# Altium schematic sheet RUMBA_plus.SchDoc
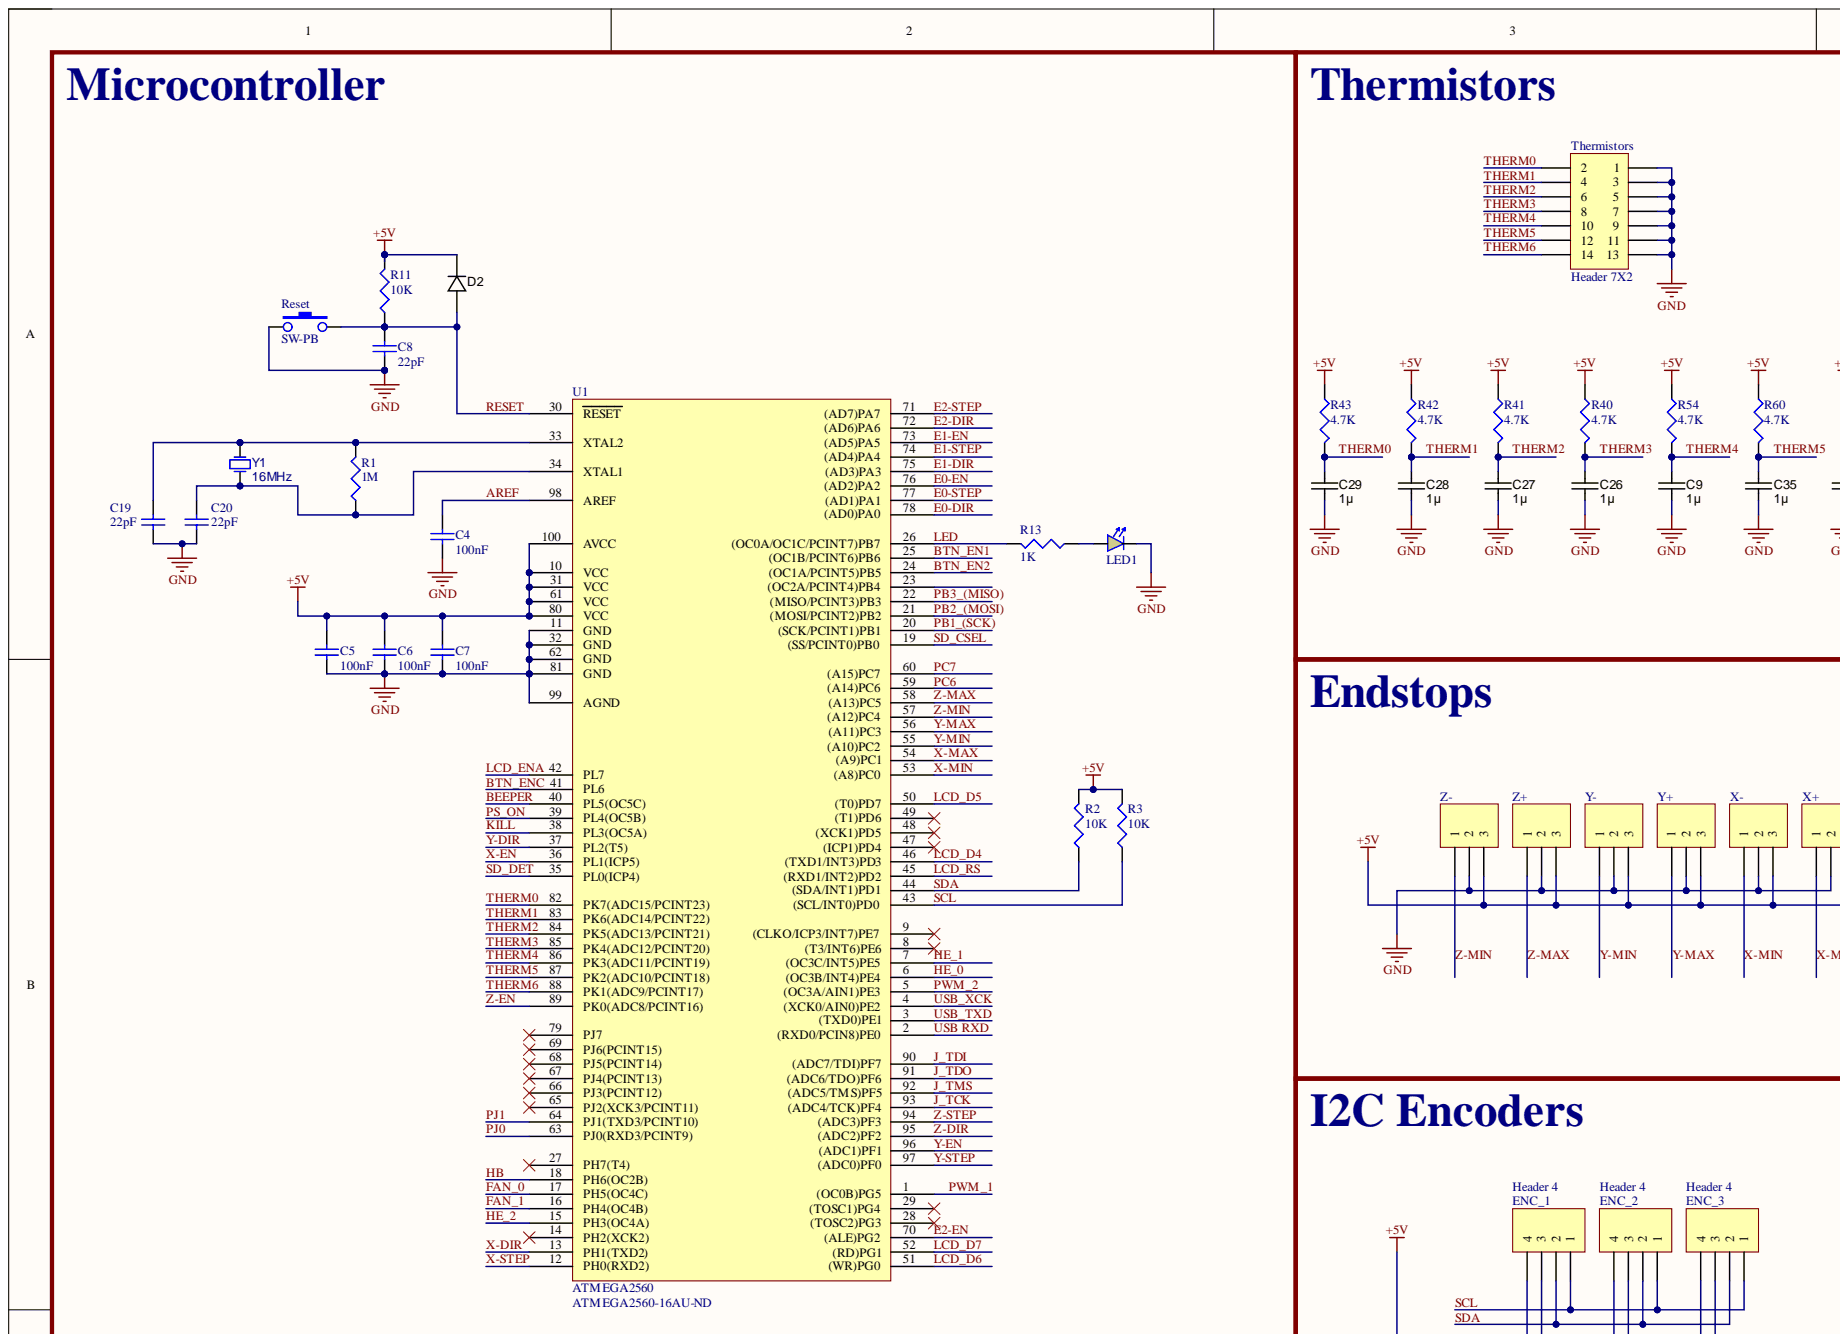
[diagram p0: RUMBA_plus.SchDoc, top left]
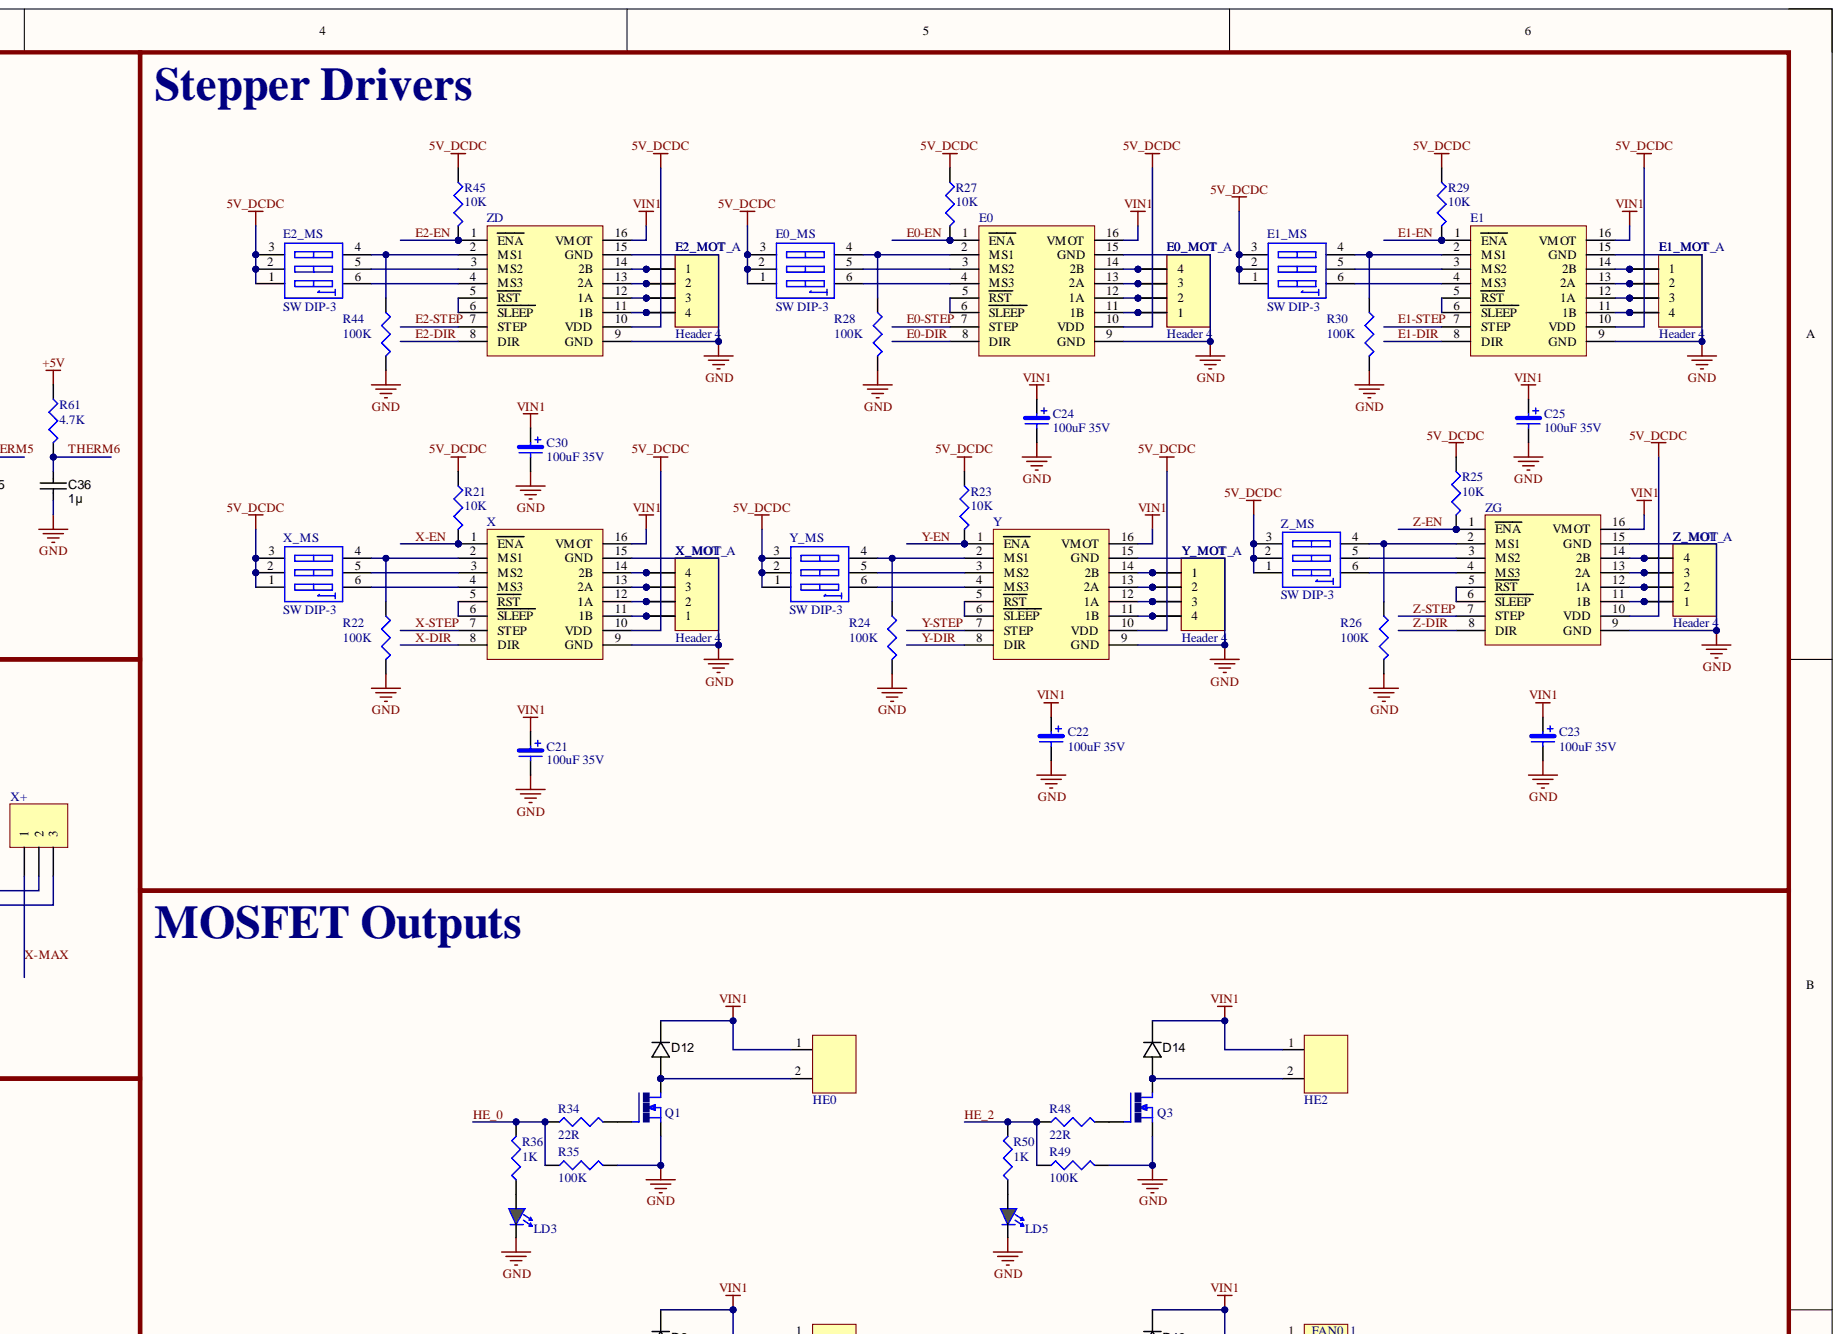
[diagram p1: RUMBA_plus.SchDoc, top right]
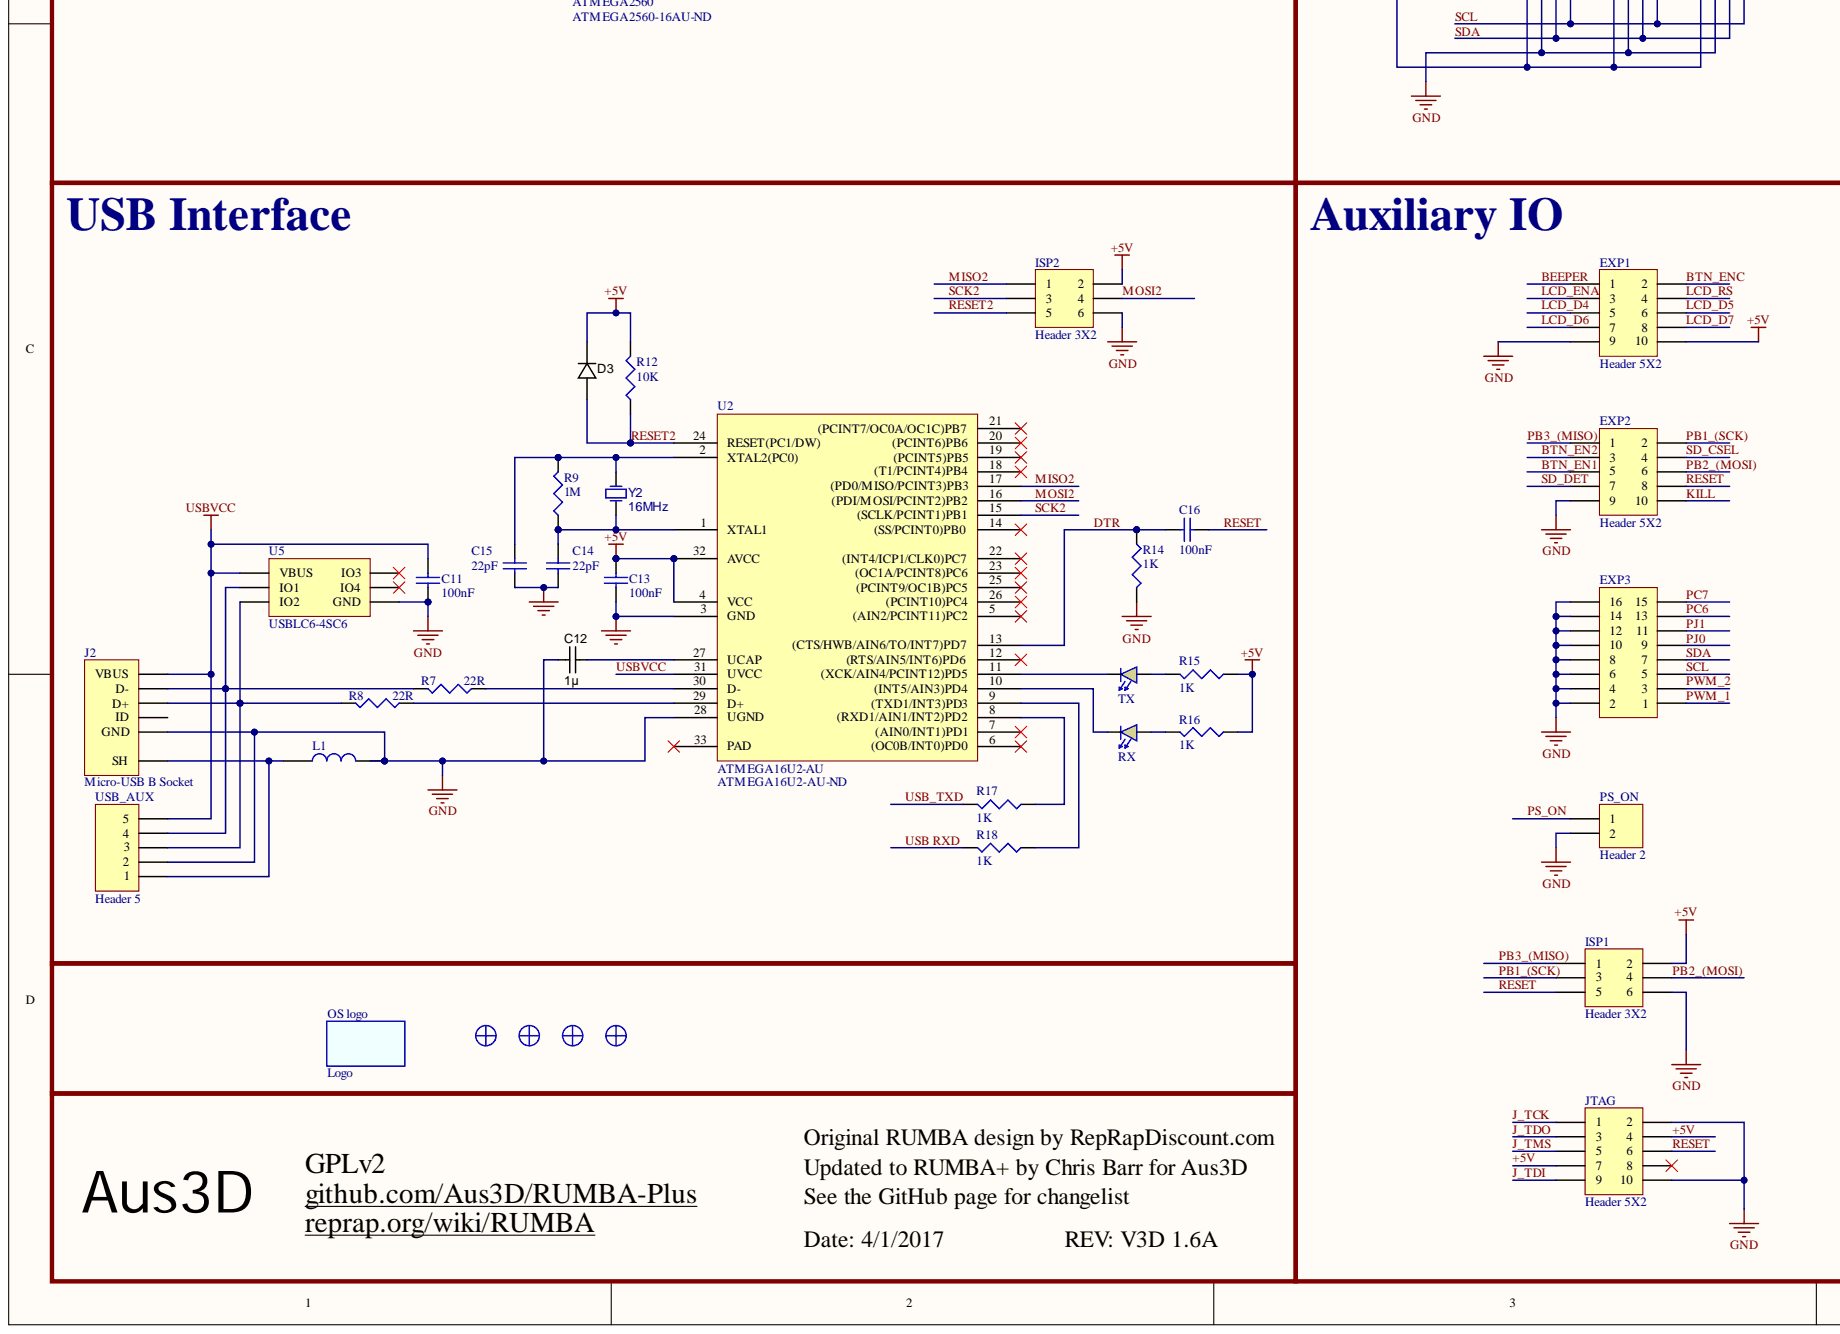
[diagram p2: RUMBA_plus.SchDoc, bottom left]
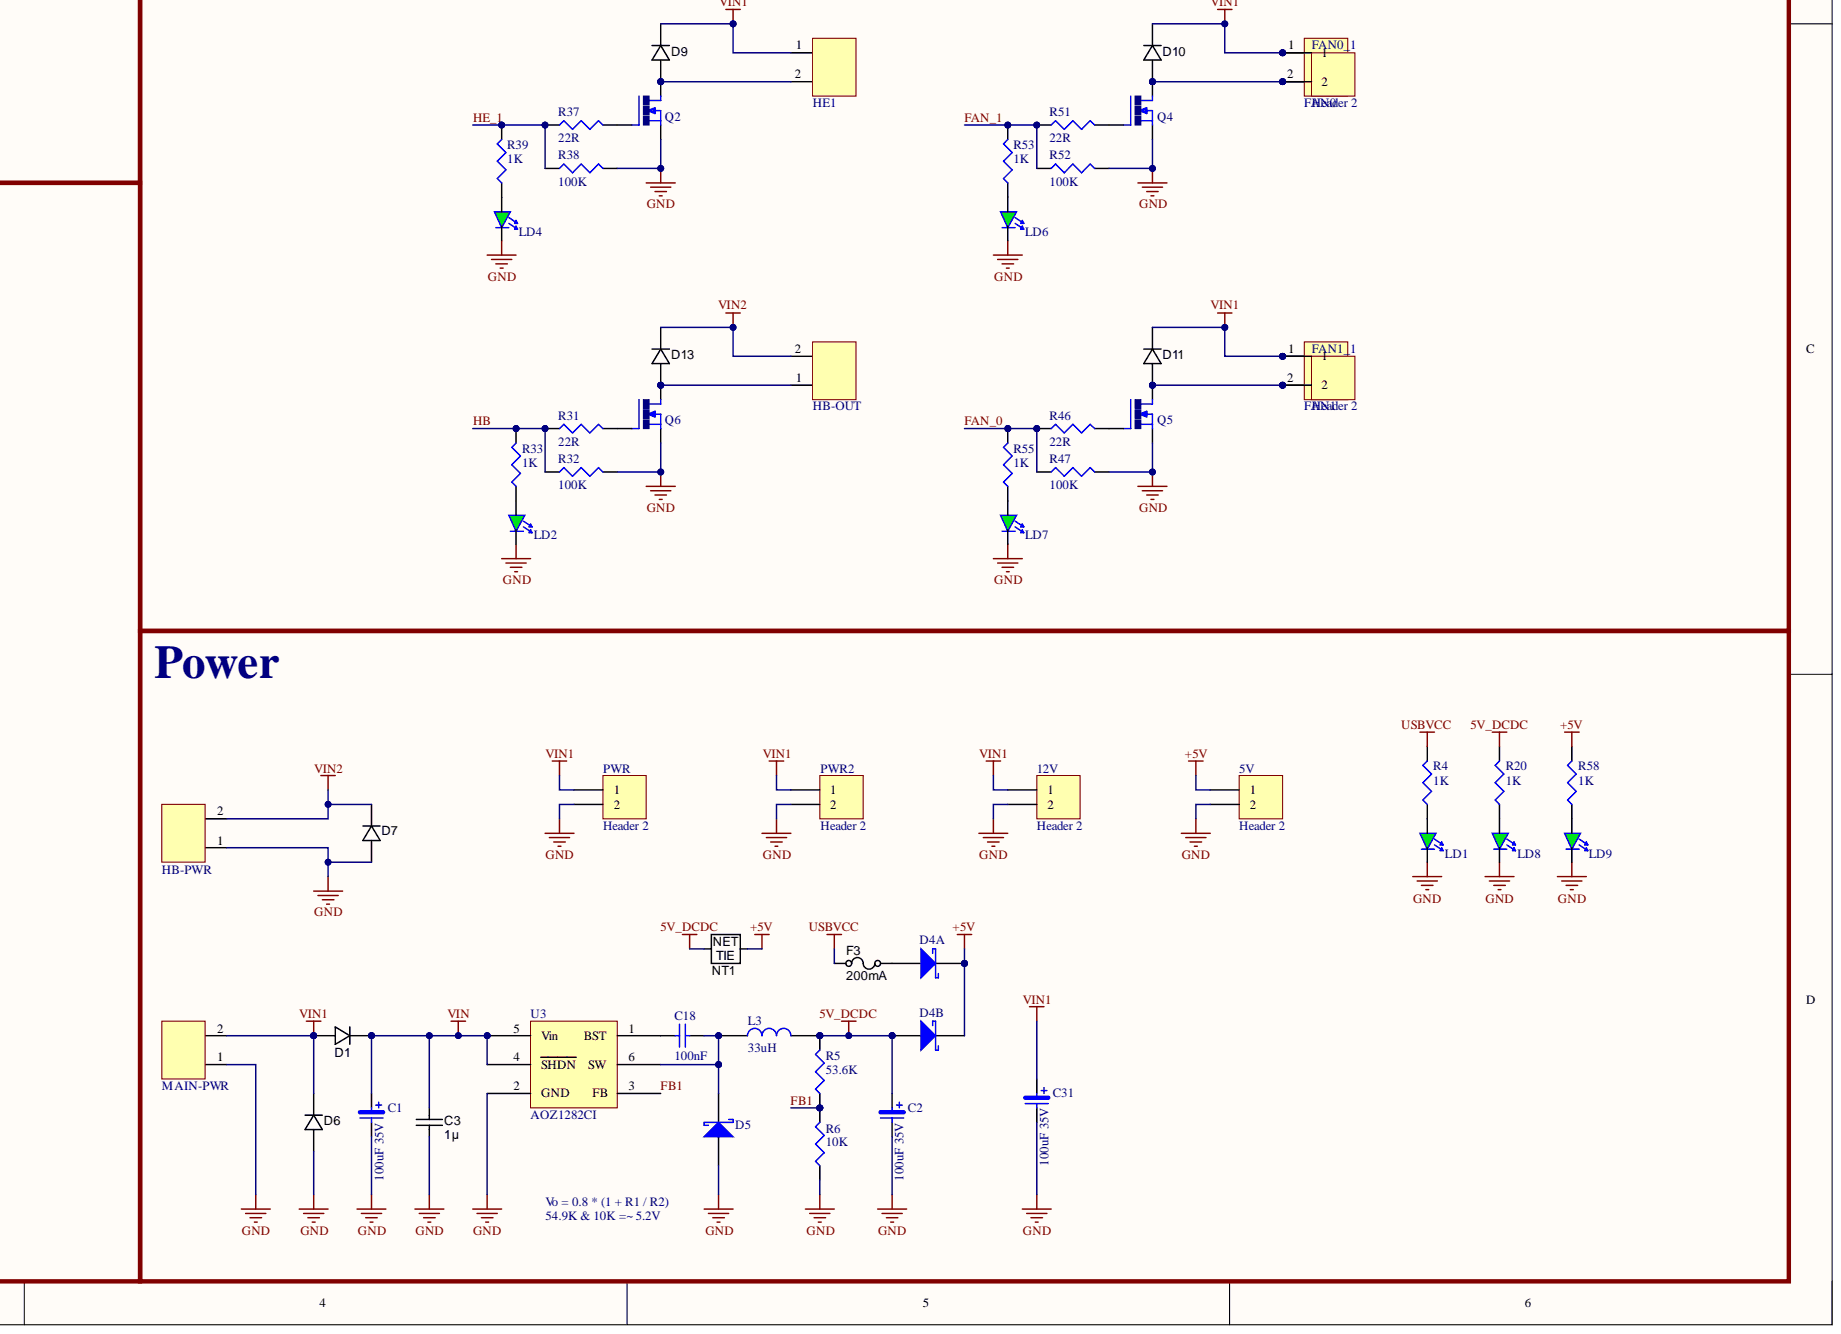
[diagram p3: RUMBA_plus.SchDoc, bottom right]

## Parts
5V  Header 2  [HDR1X2]
12V  Header 2  [HDR1X2]
C1  value 100uF 35V  [CAP EL PANASONIC]  (hidden comment: CapE-6.3-100uF-35V)
C2  value 100uF 35V  [CAP EL PANASONIC]  (hidden comment: CapE-6.3-100uF-35V)
C3  value 1µ  [Cap 1608-0603]  (hidden comment: approved sub OK)
C4  value 100nF  [Cap 1608-0603]  (hidden comment: CapC-0603-100nF)
C5  value 100nF  [Cap 1608-0603]  (hidden comment: CapC-0603-100nF)
C6  value 100nF  [Cap 1608-0603]  (hidden comment: CapC-0603-100nF)
C7  value 100nF  [Cap 1608-0603]  (hidden comment: CapC-0603-100nF)
C8  value 22pF  [Cap 1608-0603]  (hidden comment: CapC-0603-22pF)
C9  value 1µ  [Cap 1608-0603]  (hidden comment: approved sub OK)
C11  value 100nF  [Cap 1608-0603]  (hidden comment: CapC-0603-100nF)
C12  value 1µ  [Cap 1608-0603]  (hidden comment: approved sub OK)
C13  value 100nF  [Cap 1608-0603]  (hidden comment: CapC-0603-100nF)
C14  value 22pF  [Cap 1608-0603]  (hidden comment: CapC-0603-22pF)
C15  value 22pF  [Cap 1608-0603]  (hidden comment: CapC-0603-22pF)
C16  value 100nF  [Cap 1608-0603]  (hidden comment: CapC-0603-100nF)
C18  value 100nF  [Cap 1608-0603]  (hidden comment: CapC-0603-100nF)
C19  value 22pF  [Cap 1608-0603]  (hidden comment: CapC-0603-22pF)
C20  value 22pF  [Cap 1608-0603]  (hidden comment: CapC-0603-22pF)
C21  value 100uF 35V  [CAP EL PANASONIC]  (hidden comment: CapE-6.3-100uF-35V)
C22  value 100uF 35V  [CAP EL PANASONIC]  (hidden comment: CapE-6.3-100uF-35V)
C23  value 100uF 35V  [CAP EL PANASONIC]  (hidden comment: CapE-6.3-100uF-35V)
C24  value 100uF 35V  [CAP EL PANASONIC]  (hidden comment: CapE-6.3-100uF-35V)
C25  value 100uF 35V  [CAP EL PANASONIC]  (hidden comment: CapE-6.3-100uF-35V)
C26  value 1µ  [Cap 1608-0603]  (hidden comment: approved sub OK)
C27  value 1µ  [Cap 1608-0603]  (hidden comment: approved sub OK)
C28  value 1µ  [Cap 1608-0603]  (hidden comment: approved sub OK)
C29  value 1µ  [Cap 1608-0603]  (hidden comment: approved sub OK)
C30  value 100uF 35V  [CAP EL PANASONIC]  (hidden comment: CapE-6.3-100uF-35V)
C31  value 100uF 35V  [CAP EL PANASONIC]  (hidden comment: CapE-6.3-100uF-35V)
C35  value 1µ  [Cap 1608-0603]  (hidden comment: approved sub OK)
C36  value 1µ  [Cap 1608-0603]  (hidden comment: approved sub OK)
D1  100V; 1.5A  [DO-214AC]  (value not drawn)
D2  DIODE_75V_500mW  [SOD323]  (value not drawn)
D3  DIODE_75V_500mW  [SOD323]  (value not drawn)
D4  Diode Schottky 2A 20V Dual Common Cathode  [SOT1061]  (value not drawn)  (no BOM)  (parts A,B)
D5  Diode Schottky 2A 40V  [DIOM2616X08N]  (value not drawn)
D6  100V; 1.5A  [DO-214AC]  (value not drawn)
D7  100V; 1.5A  [DO-214AC]  (value not drawn)
D9  100V; 1.5A  [DO-214AC]  (value not drawn)
D10  100V; 1.5A  [DO-214AC]  (value not drawn)
D11  100V; 1.5A  [DO-214AC]  (value not drawn)
D12  100V; 1.5A  [DO-214AC]  (value not drawn)
D13  100V; 1.5A  [DO-214AC]  (value not drawn)
D14  100V; 1.5A  [DO-214AC]  (value not drawn)
E0  Header, 8-Pin, Female  [A4988BREAKOUT]  (value not drawn)
E0_MOT  Header 4  [HDR1X4]  (no BOM)
E0_MOT_A  ScrewTerminal-3.5mm-4Way  [TERM4 3.5mm]  (value not drawn)
E0_MS  SW DIP-3  [dipsw SO6]
E1  Header, 8-Pin, Female  [A4988BREAKOUT]  (value not drawn)
E1_MOT  Header 4  [HDR1X4]  (no BOM)
E1_MOT_A  ScrewTerminal-3.5mm-4Way  [TERM4 3.5mm]  (value not drawn)
E1_MS  SW DIP-3  [dipsw SO6]
E2_MOT  Header 4  [HDR1X4]  (no BOM)
E2_MOT_A  ScrewTerminal-3.5mm-4Way  [TERM4 3.5mm]  (value not drawn)
E2_MS  SW DIP-3  [dipsw SO6]
ENC_1  Header 4  [HDR1X4]  (no BOM)
ENC_2  Header 4  [HDR1X4]  (no BOM)
ENC_3  Header 4  [HDR1X4]  (no BOM)
EXP1  Header 5X2  [HDR2X5_exp Iner only\]
EXP2  Header 5X2  [HDR2X5_exp Iner only\]
EXP3  Header 8X2  [HDR2X8-HEADER]  (value not drawn)
F3  value 200mA  [PTC Fuse1608-0603]  (hidden comment: PTC Resettable Fuse)  (no BOM)
FAN0  Header 2  [HDR1X2]
FAN0_1  Screw Terminal 3.5mm 2-Way  [TERM2 3.5mm]  (value not drawn)
FAN1  Header 2  [HDR1X2]
FAN1_1  Screw Terminal 3.5mm 2-Way  [TERM2 3.5mm]  (value not drawn)
HB-OUT  Screw Terminal 5mm 2-Way  [TERM2 5MM]  (value not drawn)
HB-PWR  Screw Terminal 5mm 2-Way  [TERM2 5MM]  (value not drawn)
HE0  Screw Terminal 3.5mm 2-Way  [TERM2 3.5mm]  (value not drawn)
HE1  Screw Terminal 3.5mm 2-Way  [TERM2 3.5mm]  (value not drawn)
HE2  Screw Terminal 3.5mm 2-Way  [TERM2 3.5mm]  (value not drawn)
ISP1  Header 3X2  [HDR2X3]  (no BOM)
ISP2  Header 3X2  [HDR2X3]  (no BOM)
J2  Micro-USB B Socket  [Micro-USB B Molex 105017-0001]  (no BOM)
JTAG  Header 5X2  [HDR2X5]  (no BOM)
L1  Ferrite Bead SMD 0805  [Res 2012-0805]  (value not drawn)
L3  value 33uH  [SRN6045TA SMD Inductor]  (hidden comment: Inductor SMD 33uH 2.5A)
LD1  GREEN  [LED 1608-0603]  (value not drawn)
LD2  GREEN  [LED 1608-0603]  (value not drawn)
LD3  GREEN  [LED 1608-0603]  (value not drawn)
LD4  GREEN  [LED 1608-0603]  (value not drawn)
LD5  GREEN  [LED 1608-0603]  (value not drawn)
LD6  GREEN  [LED 1608-0603]  (value not drawn)
LD7  GREEN  [LED 1608-0603]  (value not drawn)
LD8  GREEN  [LED 1608-0603]  (value not drawn)
LD9  GREEN  [LED 1608-0603]  (value not drawn)
LED1  YELLOW  [LED 1608-0603]  (value not drawn)
MAIN-PWR  Screw Terminal 5mm 2-Way  [TERM2 5MM]  (value not drawn)
NT1  Net Tie  [NetTie_External_24mil]  (value not drawn)
OS_logo  Logo  [OS LOGO TOP]  (no BOM)
PS_ON  Header 2  [HDR1X2]  (no BOM)
PWR  Header 2  [HDR1X2]
PWR2  Header 2  [HDR1X2]
Q1  BUK9Y21-40E  [LFPACK]  (value not drawn)
Q2  BUK9Y21-40E  [LFPACK]  (value not drawn)
Q3  BUK9Y21-40E  [LFPACK]  (value not drawn)
Q4  BUK9Y21-40E  [LFPACK]  (value not drawn)
Q5  BUK9Y21-40E  [LFPACK]  (value not drawn)
Q6  PSMN1R8-40YLC  [LFPACK]  (value not drawn)
R1  value 1M  [RES 1608-0603]  (hidden comment: Res-0603-1M)
R2  value 10K  [RES 1608-0603]  (hidden comment: Res-0603-10K)
R3  value 10K  [RES 1608-0603]  (hidden comment: Res-0603-10K)
R4  value 1K  [RES 1608-0603]  (hidden comment: Res-0603-1K)
R5  value 53.6K  [RES 1608-0603]  (hidden comment: Res-0603-53.6K)
R6  value 10K  [RES 1608-0603]  (hidden comment: Res-0603-10K)
R7  value 22R  [RES 1608-0603]  (hidden comment: Res-0603-22R)
R8  value 22R  [RES 1608-0603]  (hidden comment: Res-0603-22R)
R9  value 1M  [RES 1608-0603]  (hidden comment: Res-0603-1M)
R11  value 10K  [RES 1608-0603]  (hidden comment: Res-0603-10K)
R12  value 10K  [RES 1608-0603]  (hidden comment: Res-0603-10K)
R13  value 1K  [RES 1608-0603]  (hidden comment: Res-0603-1K)
R14  value 1K  [RES 1608-0603]  (hidden comment: Res-0603-1K)
R15  value 1K  [RES 1608-0603]  (hidden comment: Res-0603-1K)
R16  value 1K  [RES 1608-0603]  (hidden comment: Res-0603-1K)
R17  value 1K  [RES 1608-0603]  (hidden comment: Res-0603-1K)
R18  value 1K  [RES 1608-0603]  (hidden comment: Res-0603-1K)
R20  value 1K  [RES 1608-0603]  (hidden comment: Res-0603-1K)
R21  value 10K  [RES 1608-0603]  (hidden comment: Res-0603-10K)
R22  value 100K  [RES 1608-0603]  (hidden comment: Res-0603-100K)
R23  value 10K  [RES 1608-0603]  (hidden comment: Res-0603-10K)
R24  value 100K  [RES 1608-0603]  (hidden comment: Res-0603-100K)
R25  value 10K  [RES 1608-0603]  (hidden comment: Res-0603-10K)
R26  value 100K  [RES 1608-0603]  (hidden comment: Res-0603-100K)
R27  value 10K  [RES 1608-0603]  (hidden comment: Res-0603-10K)
R28  value 100K  [RES 1608-0603]  (hidden comment: Res-0603-100K)
R29  value 10K  [RES 1608-0603]  (hidden comment: Res-0603-10K)
R30  value 100K  [RES 1608-0603]  (hidden comment: Res-0603-100K)
R31  value 22R  [RES 1608-0603]  (hidden comment: Res-0603-22R)
R32  value 100K  [RES 1608-0603]  (hidden comment: Res-0603-100K)
R33  value 1K  [RES 1608-0603]  (hidden comment: Res-0603-1K)
R34  value 22R  [RES 1608-0603]  (hidden comment: Res-0603-22R)
R35  value 100K  [RES 1608-0603]  (hidden comment: Res-0603-100K)
R36  value 1K  [RES 1608-0603]  (hidden comment: Res-0603-1K)
R37  value 22R  [RES 1608-0603]  (hidden comment: Res-0603-22R)
R38  value 100K  [RES 1608-0603]  (hidden comment: Res-0603-100K)
R39  value 1K  [RES 1608-0603]  (hidden comment: Res-0603-1K)
R40  value 4.7K  [RES 1608-0603]  (hidden comment: Res-0603-4K7)
R41  value 4.7K  [RES 1608-0603]  (hidden comment: Res-0603-4K7)
R42  value 4.7K  [RES 1608-0603]  (hidden comment: Res-0603-4K7)
R43  value 4.7K  [RES 1608-0603]  (hidden comment: Res-0603-4K7)
R44  value 100K  [RES 1608-0603]  (hidden comment: Res-0603-100K)
R45  value 10K  [RES 1608-0603]  (hidden comment: Res-0603-10K)
R46  value 22R  [RES 1608-0603]  (hidden comment: Res-0603-22R)
R47  value 100K  [RES 1608-0603]  (hidden comment: Res-0603-100K)
R48  value 22R  [RES 1608-0603]  (hidden comment: Res-0603-22R)
R49  value 100K  [RES 1608-0603]  (hidden comment: Res-0603-100K)
R50  value 1K  [RES 1608-0603]  (hidden comment: Res-0603-1K)
R51  value 22R  [RES 1608-0603]  (hidden comment: Res-0603-22R)
R52  value 100K  [RES 1608-0603]  (hidden comment: Res-0603-100K)
R53  value 1K  [RES 1608-0603]  (hidden comment: Res-0603-1K)
R54  value 4.7K  [RES 1608-0603]  (hidden comment: Res-0603-4K7)
R55  value 1K  [RES 1608-0603]  (hidden comment: Res-0603-1K)
R58  value 1K  [RES 1608-0603]  (hidden comment: Res-0603-1K)
R60  value 4.7K  [RES 1608-0603]  (hidden comment: Res-0603-4K7)
R61  value 4.7K  [RES 1608-0603]  (hidden comment: Res-0603-4K7)
Reset  SW-PB  [SW-PB SMD 2pin]  (no BOM)
RX  YELLOW  [LED 1608-0603]  (value not drawn)
sc1  Sraf  [Sraf 3mm - rupa 3.2]  (value not drawn)  (no BOM)
sc2  Sraf  [Sraf 3mm - rupa 3.2]  (value not drawn)  (no BOM)
sc3  Sraf  [Sraf 3mm - rupa 3.5]  (value not drawn)  (no BOM)
sc4  Sraf  [Sraf 3mm - rupa 3.5]  (value not drawn)  (no BOM)
Thermistors  Header 7X2  [HDR2X7]
TX  YELLOW  [LED 1608-0603]  (value not drawn)
U1  ATMEGA2560  [TQFP100N]
U2  ATMEGA16U2-AU  [TQFP32N]
U3  AOZ1282CI  [SOT23-6N]
U5  USBLC6-4SC6  [SOT23-6N]
USB_AUX  Header 5  [HDR1X5-HEADER]  (no BOM)
X  Header, 8-Pin, Female  [A4988BREAKOUT]  (value not drawn)
X+  Header 3  [HDR1X3]  (value not drawn)
X-  Header 3  [HDR1X3]  (value not drawn)
X_MOT  Header 4  [HDR1X4]  (no BOM)
X_MOT_A  ScrewTerminal-3.5mm-4Way  [TERM4 3.5mm]  (value not drawn)
X_MS  SW DIP-3  [dipsw SO6]
Y  Header, 8-Pin, Female  [A4988BREAKOUT]  (value not drawn)
Y1  16MHz  [3525 SMD Crystal]
Y2  16MHz  [3525 SMD Crystal]
Y+  Header 3  [HDR1X3]  (value not drawn)
Y-  Header 3  [HDR1X3]  (value not drawn)
Y_MOT  Header 4  [HDR1X4]  (no BOM)
Y_MOT_A  ScrewTerminal-3.5mm-4Way  [TERM4 3.5mm]  (value not drawn)
Y_MS  SW DIP-3  [dipsw SO6]
Z+  Header 3  [HDR1X3]  (value not drawn)
Z-  Header 3  [HDR1X3]  (value not drawn)
Z_MOT  Header 4  [HDR1X4]  (no BOM)
Z_MOT_A  ScrewTerminal-3.5mm-4Way  [TERM4 3.5mm]  (value not drawn)
Z_MS  SW DIP-3  [dipsw SO6]
ZD  Header, 8-Pin, Female  [A4988BREAKOUT]  (value not drawn)
ZG  Header, 8-Pin, Female  [A4988BREAKOUT]  (value not drawn)

## Nets
GND: 5V.2 12V.2 C1.2 C2.2 C3.2 C4.1 C5.1 C6.1 C7.1 C8.1 C9.2 C11.1 C12.2 C13.1 C14.1 C15.1 C19.1 C20.1 C21.2 C22.2 C23.2 C24.2 C25.2 C26.2 C27.2 C28.2 C29.2 C30.2 C31.2 C35.2 C36.2 D5.A D6.A D7.A E0.9(GND) E0.15(GND) E1.9(GND) E1.15(GND) ENC_1.3 ENC_2.3 ENC_3.3 EXP1.9 EXP2.9 EXP3.2 EXP3.4 EXP3.6 EXP3.8 EXP3.10 EXP3.12 EXP3.14 EXP3.16 HB-PWR.1 ISP1.6 ISP2.6 J2.GND JTAG.2 JTAG.10 L1.2 LD1.2 LD2.2 LD3.2 LD4.2 LD5.2 LD6.2 LD7.2 LD8.2 LD9.2 LED1.2 MAIN-PWR.1 PS_ON.2 PWR.2 PWR2.2 Q1.3 Q2.3 Q3.3 Q4.3 Q5.3 Q6.3 R6.1 R14.2 R22.2 R24.2 R26.2 R28.2 R30.2 R32.2 R35.2 R38.2 R44.2 R47.2 R49.2 R52.2 Reset.1 Thermistors.1 Thermistors.3 Thermistors.5 Thermistors.7 Thermistors.9 Thermistors.11 Thermistors.13 U1.11(GND) U1.32(GND) U1.62(GND) U1.81(GND) U1.99(AGND) U2.3(GND) U2.28(UGND) U3.2(GND) U5.2(GND) USB_AUX.2 X.9(GND) X.15(GND) X+.2 X-.2 Y.9(GND) Y.15(GND) Y+.2 Y-.2 Z+.2 Z-.2 ZD.9(GND) ZD.15(GND) ZG.9(GND) ZG.15(GND)
VIN: C1.1 C3.1 D1.K U3.4(SHDN) U3.5(Vin)
VIN1: 12V.1 C21.1 C22.1 C23.1 C24.1 C25.1 C30.1 C31.1 D1.A D6.K D9.K D10.K D11.K D12.K D14.K E0.16(VM_OT) E1.16(VM_OT) FAN0.1 FAN0_1.1 FAN1.1 FAN1_1.1 HE0.1 HE1.1 HE2.1 MAIN-PWR.2 PWR.1 PWR2.1 X.16(VM_OT) Y.16(VM_OT) ZD.16(VM_OT) ZG.16(VM_OT)
VIN2: D7.K D13.K HB-OUT.2 HB-PWR.2
5V_DCDC: 5V.1 C2.1 C5.2 C6.2 C7.2 C13.2 D2.K D3.K D4.2 D4.3 E0.10(VDD) E0_MS.1 E0_MS.2 E0_MS.3 E1.10(VDD) E1_MS.1 E1_MS.2 E1_MS.3 E2_MS.1 E2_MS.2 E2_MS.3 ENC_1.4 ENC_2.4 ENC_3.4 EXP1.10 ISP1.2 ISP2.2 JTAG.4 JTAG.7 L3.2 NT1.1 NT1.2 R2.2 R3.2 R5.2 R11.2 R12.2 R15.1 R16.1 R20.2 R21.1 R23.1 R25.1 R27.1 R29.1 R40.1 R41.1 R42.1 R43.1 R45.1 R54.1 R58.2 R60.1 R61.1 U1.10(VCC) U1.31(VCC) U1.61(VCC) U1.80(VCC) U1.100(AVCC) U2.4(VCC) U2.32(AVCC) X.10(VDD) X+.3 X-.3 X_MS.1 X_MS.2 X_MS.3 Y.10(VDD) Y+.3 Y-.3 Y_MS.1 Y_MS.2 Y_MS.3 Z+.3 Z-.3 Z_MS.1 Z_MS.2 Z_MS.3 ZD.10(VDD) ZG.10(VDD)  [also labelled +5V]
AREF: C4.2 U1.98(AREF)
BEEPER: EXP1.1 U1.40(PL5(OC5C))
BTN_EN1: EXP2.5 U1.25((OC1B/PCINT6)PB6)
BTN_EN2: EXP2.3 U1.24((OC1A/PCINT5)PB5)
BTN_ENC: EXP1.2 U1.41(PL6)
DTR: C16.2 R14.1 U2.13((CTS/HWB/AIN6/TO/INT7)PD7)
E0-DIR: E0.8(DIR) U1.78((AD0)PA0)
E0-EN: E0.1(ENA) R27.2 U1.76((AD2)PA2)
E0-STEP: E0.7(STEP) U1.77((AD1)PA1)
E1-DIR: E1.8(DIR) U1.75((AD3)PA3)
E1-EN: E1.1(ENA) R29.2 U1.73((AD5)PA5)
E1-STEP: E1.7(STEP) U1.74((AD4)PA4)
E2-DIR: U1.72((AD6)PA6) ZD.8(DIR)
E2-EN: R45.2 U1.70((ALE)PG2) ZD.1(ENA)
E2-STEP: U1.71((AD7)PA7) ZD.7(STEP)
FAN_0: R46.1 R47.1 R55.2 U1.17(PH5(OC4C))
FAN_1: R51.1 R52.1 R53.2 U1.16(PH4(OC4B))
FB1: R5.1 R6.2 U3.3(FB)
HB: R31.1 R32.1 R33.2 U1.18(PH6(OC2B))
HE_0: R34.1 R35.1 R36.2 U1.6((OC3B/INT4)PE4)
HE_1: R37.1 R38.1 R39.2 U1.7((OC3C/INT5)PE5)
HE_2: R48.1 R49.1 R50.2 U1.15(PH3(OC4A))
J_TCK: JTAG.1 U1.93((ADC4/TCK)PF4)
J_TDI: JTAG.9 U1.90((ADC7/TDI)PF7)
J_TDO: JTAG.3 U1.91((ADC6/TDO)PF6)
J_TMS: JTAG.5 U1.92((ADC5/TM_S)PF5)
KILL: EXP2.10 U1.38(PL3(OC5A))
LCD_D4: EXP1.5 U1.46((TXD1/INT3)PD3)
LCD_D5: EXP1.6 U1.50((T0)PD7)
LCD_D6: EXP1.7 U1.51((WR)PG0)
LCD_D7: EXP1.8 U1.52((RD)PG1)
LCD_ENA: EXP1.3 U1.42(PL7)
LCD_RS: EXP1.4 U1.45((RXD1/INT2)PD2)
LED: R13.2 U1.26((OC0A/OC1C/PCINT7)PB7)
MISO2: ISP2.1 U2.17((PD0/M_ISO/PCINT3)PB3)
MOSI2: ISP2.4 U2.16((PDI/M_OSI/PCINT2)PB2)
PB1_(SCK): EXP2.2 ISP1.3 U1.20((SCK/PCINT1)PB1)
PB2_(MOSI): EXP2.6 ISP1.4 U1.21((MOSI/PCINT2)PB2)
PB3_(MISO): EXP2.1 ISP1.1 U1.22((MISO/PCINT3)PB3)
PC6: EXP3.13 U1.59((A14)PC6)
PC7: EXP3.15 U1.60((A15)PC7)
PJ0: EXP3.9 U1.63(PJ0(RXD3/PCINT9))
PJ1: EXP3.11 U1.64(PJ1(TXD3/PCINT10))
PS_ON: PS_ON.1 U1.39(PL4(OC5B))
PWM_1: EXP3.1 U1.1((OC0B)PG5)
PWM_2: EXP3.3 U1.5((OC3A/AIN1)PE3)
RESET: C8.2 C16.1 D2.A EXP2.8 ISP1.5 JTAG.6 R11.1 Reset.2 U1.30(RESET)
RESET2: D3.A ISP2.5 R12.1 U2.24(RESET(PC1/DW))
SCK2: ISP2.3 U2.15((SCLK/PCINT1)PB1)
SCL: ENC_1.1 ENC_2.1 ENC_3.1 EXP3.5 R3.1 U1.43((SCL/INT0)PD0)
SD_CSEL: EXP2.4 U1.19((SS/PCINT0)PB0)
SD_DET: EXP2.7 U1.35(PL0(ICP4))
SDA: ENC_1.2 ENC_2.2 ENC_3.2 EXP3.7 R2.1 U1.44((SDA/INT1)PD1)
THERM0: C29.1 R43.2 Thermistors.2 U1.82(PK7(ADC15/PCINT23))
THERM1: C28.1 R42.2 Thermistors.4 U1.83(PK6(ADC14/PCINT22))
THERM2: C27.1 R41.2 Thermistors.6 U1.84(PK5(ADC13/PCINT21))
THERM3: C26.1 R40.2 Thermistors.8 U1.85(PK4(ADC12/PCINT20))
THERM4: C9.1 R54.2 Thermistors.10 U1.86(PK3(ADC11/PCINT19))
THERM5: C35.1 R60.2 Thermistors.12 U1.87(PK2(ADC10/PCINT18))
THERM6: C36.1 R61.2 Thermistors.14 U1.88(PK1(ADC9/PCINT17))
USB RXD: R18.2 U1.2((RXD0/PCIN8)PE0)
USB_TXD: R17.2 U1.3((TXD0)PE1)
USB_XCK: U1.4((XCK0/AIN0)PE2)
USBVCC: C11.2 F3.1 J2.VBUS R4.2 U2.31(UVCC) U5.5(VBUS) USB_AUX.5
X-DIR: U1.13(PH1(TXD2)) X.8(DIR)
X-EN: R21.2 U1.36(PL1(ICP5)) X.1(ENA)
X-MAX: U1.54((A9)PC1) X+.1
X-MIN: U1.53((A8)PC0) X-.1
X-STEP: U1.12(PH0(RXD2)) X.7(STEP)
Y-DIR: U1.37(PL2(T5)) Y.8(DIR)
Y-EN: R23.2 U1.96((ADC1)PF1) Y.1(ENA)
Y-MAX: U1.56((A11)PC3) Y+.1
Y-MIN: U1.55((A10)PC2) Y-.1
Y-STEP: U1.97((ADC0)PF0) Y.7(STEP)
Z-DIR: U1.95((ADC2)PF2) ZG.8(DIR)
Z-EN: R25.2 U1.89(PK0(ADC8/PCINT16)) ZG.1(ENA)
Z-MAX: U1.58((A13)PC5) Z+.1
Z-MIN: U1.57((A12)PC4) Z-.1
Z-STEP: U1.94((ADC3)PF3) ZG.7(STEP)
NetC12_1: C12.1 U2.27(UCAP)
NetC14_2: C14.2 R9.1 U2.1(XTAL1) Y2.1
NetC15_2: C15.2 R9.2 U2.2(XTAL2(PC0)) Y2.3
NetC18_1: C18.1 D5.K L3.1 U3.6(SW)
NetC18_2: C18.2 U3.1(BST)
NetC19_2: C19.2 R1.2 U1.33(XTAL2) Y1.3
NetC20_2: C20.2 R1.1 U1.34(XTAL1) Y1.1
NetD4_1: D4.1 F3.2
NetD9_A: D9.A HE1.2 Q2.2
NetD10_A: D10.A FAN0.2 FAN0_1.2 Q4.2
NetD11_A: D11.A FAN1.2 FAN1_1.2 Q5.2
NetD12_A: D12.A HE0.2 Q1.2
NetD13_A: D13.A HB-OUT.1 Q6.2
NetD14_A: D14.A HE2.2 Q3.2
NetE0_2: E0.2(M_S1) E0_MS.4 R28.1
NetE0_3: E0.3(M_S2) E0_MS.5
NetE0_4: E0.4(M_S3) E0_MS.6
NetE0_5: E0.5(RST) E0.6(SLEEP)
NetE0_11: E0.11(1B) E0_MOT.1 E0_MOT_A.4
NetE0_12: E0.12(1A) E0_MOT.2 E0_MOT_A.3
NetE0_13: E0.13(2A) E0_MOT.3 E0_MOT_A.2
NetE0_14: E0.14(2B) E0_MOT.4 E0_MOT_A.1
NetE1_2: E1.2(M_S1) E1_MS.4 R30.1
NetE1_3: E1.3(M_S2) E1_MS.5
NetE1_4: E1.4(M_S3) E1_MS.6
NetE1_5: E1.5(RST) E1.6(SLEEP)
NetE1_11: E1.11(1B) E1_MOT.1 E1_MOT_A.4
NetE1_12: E1.12(1A) E1_MOT.2 E1_MOT_A.3
NetE1_13: E1.13(2A) E1_MOT.3 E1_MOT_A.2
NetE1_14: E1.14(2B) E1_MOT.4 E1_MOT_A.1
NetE2_MOT_1: E2_MOT.1 E2_MOT_A.4 ZD.11(1B)
NetE2_MOT_2: E2_MOT.2 E2_MOT_A.3 ZD.12(1A)
NetE2_MOT_3: E2_MOT.3 E2_MOT_A.2 ZD.13(2A)
NetE2_MOT_4: E2_MOT.4 E2_MOT_A.1 ZD.14(2B)
NetE2_MS_4: E2_MS.4 R44.1 ZD.2(M_S1)
NetE2_MS_5: E2_MS.5 ZD.3(M_S2)
NetE2_MS_6: E2_MS.6 ZD.4(M_S3)
NetJ2_D+: J2.D+ R8.2 U5.3(IO2) USB_AUX.3
NetJ2_D-: J2.D- R7.2 U5.1(IO1) USB_AUX.4
NetJ2_SHIELD: J2.SHIELD(SH) L1.1 USB_AUX.1
NetLD1_1: LD1.1 R4.1
NetLD2_1: LD2.1 R33.1
NetLD3_1: LD3.1 R36.1
NetLD4_1: LD4.1 R39.1
NetLD5_1: LD5.1 R50.1
NetLD6_1: LD6.1 R53.1
NetLD7_1: LD7.1 R55.1
NetLD8_1: LD8.1 R20.1
NetLD9_1: LD9.1 R58.1
NetLED1_1: LED1.1 R13.1
NetQ1_1: Q1.1 R34.2
NetQ2_1: Q2.1 R37.2
NetQ3_1: Q3.1 R48.2
NetQ4_1: Q4.1 R51.2
NetQ5_1: Q5.1 R46.2
NetQ6_1: Q6.1 R31.2
NetR7_1: R7.1 U2.30(D-)
NetR8_1: R8.1 U2.29(D+)
NetR15_2: R15.2 TX.1
NetR16_2: R16.2 RX.1
NetR17_1: R17.1 U2.8((RXD1/AIN1/INT2)PD2)
NetR18_1: R18.1 U2.9((TXD1/INT3)PD3)
NetR22_1: R22.1 X.2(M_S1) X_MS.4
NetR24_1: R24.1 Y.2(M_S1) Y_MS.4
NetR26_1: R26.1 Z_MS.4 ZG.2(M_S1)
NetRX_2: RX.2 U2.10((INT5/AIN3)PD4)
NetTX_2: TX.2 U2.11((XCK/AIN4/PCINT12)PD5)
NetX_3: X.3(M_S2) X_MS.5
NetX_4: X.4(M_S3) X_MS.6
NetX_5: X.5(RST) X.6(SLEEP)
NetX_11: X.11(1B) X_MOT.1 X_MOT_A.4
NetX_12: X.12(1A) X_MOT.2 X_MOT_A.3
NetX_13: X.13(2A) X_MOT.3 X_MOT_A.2
NetX_14: X.14(2B) X_MOT.4 X_MOT_A.1
NetY_3: Y.3(M_S2) Y_MS.5
NetY_4: Y.4(M_S3) Y_MS.6
NetY_5: Y.5(RST) Y.6(SLEEP)
NetY_11: Y.11(1B) Y_MOT.1 Y_MOT_A.4
NetY_12: Y.12(1A) Y_MOT.2 Y_MOT_A.3
NetY_13: Y.13(2A) Y_MOT.3 Y_MOT_A.2
NetY_14: Y.14(2B) Y_MOT.4 Y_MOT_A.1
NetZ_MOT_1: Z_MOT.1 Z_MOT_A.4 ZG.11(1B)
NetZ_MOT_2: Z_MOT.2 Z_MOT_A.3 ZG.12(1A)
NetZ_MOT_3: Z_MOT.3 Z_MOT_A.2 ZG.13(2A)
NetZ_MOT_4: Z_MOT.4 Z_MOT_A.1 ZG.14(2B)
NetZ_MS_5: Z_MS.5 ZG.3(M_S2)
NetZ_MS_6: Z_MS.6 ZG.4(M_S3)
NetZD_5: ZD.5(RST) ZD.6(SLEEP)
NetZG_5: ZG.5(RST) ZG.6(SLEEP)

Not connected: J2 ID; JTAG 8; U1 8((T3/INT6)PE6) 9((CLKO/ICP3/INT7)PE7) 14(PH2(XCK2)) 23((OC2A/PCINT4)PB4) 27(PH7(T4)) 28((TOSC2)PG3) 29((TOSC1)PG4) 47((ICP1)PD4) 48((XCK1)PD5) 49((T1)PD6) 65(PJ2(XCK3/PCINT11)) 66(PJ3(PCINT12)) 67(PJ4(PCINT13)) 68(PJ5(PCINT14)) 69(PJ6(PCINT15)) 79(PJ7); U2 5((AIN2/PCINT11)PC2) 6((OC0B/INT0)PD0) 7((AIN0/INT1)PD1) 12((RTS/AIN5/INT6)PD6) 14((SS/PCINT0)PB0) 18((T1/PCINT4)PB4) 19((PCINT5)PB5) 20((PCINT6)PB6) 21((PCINT7/OC0A/OC1C)PB7) 22((INT4/ICP1/CLK0)PC7) 23((OC1A/PCINT8)PC6) 25((PCINT9/OC1B)PC5) 26((PCINT10)PC4) 33(PAD); U5 4(IO3) 6(IO4)
